# Altium schematic sheet PAGE3 - HUB, USB, ETH.SchDoc
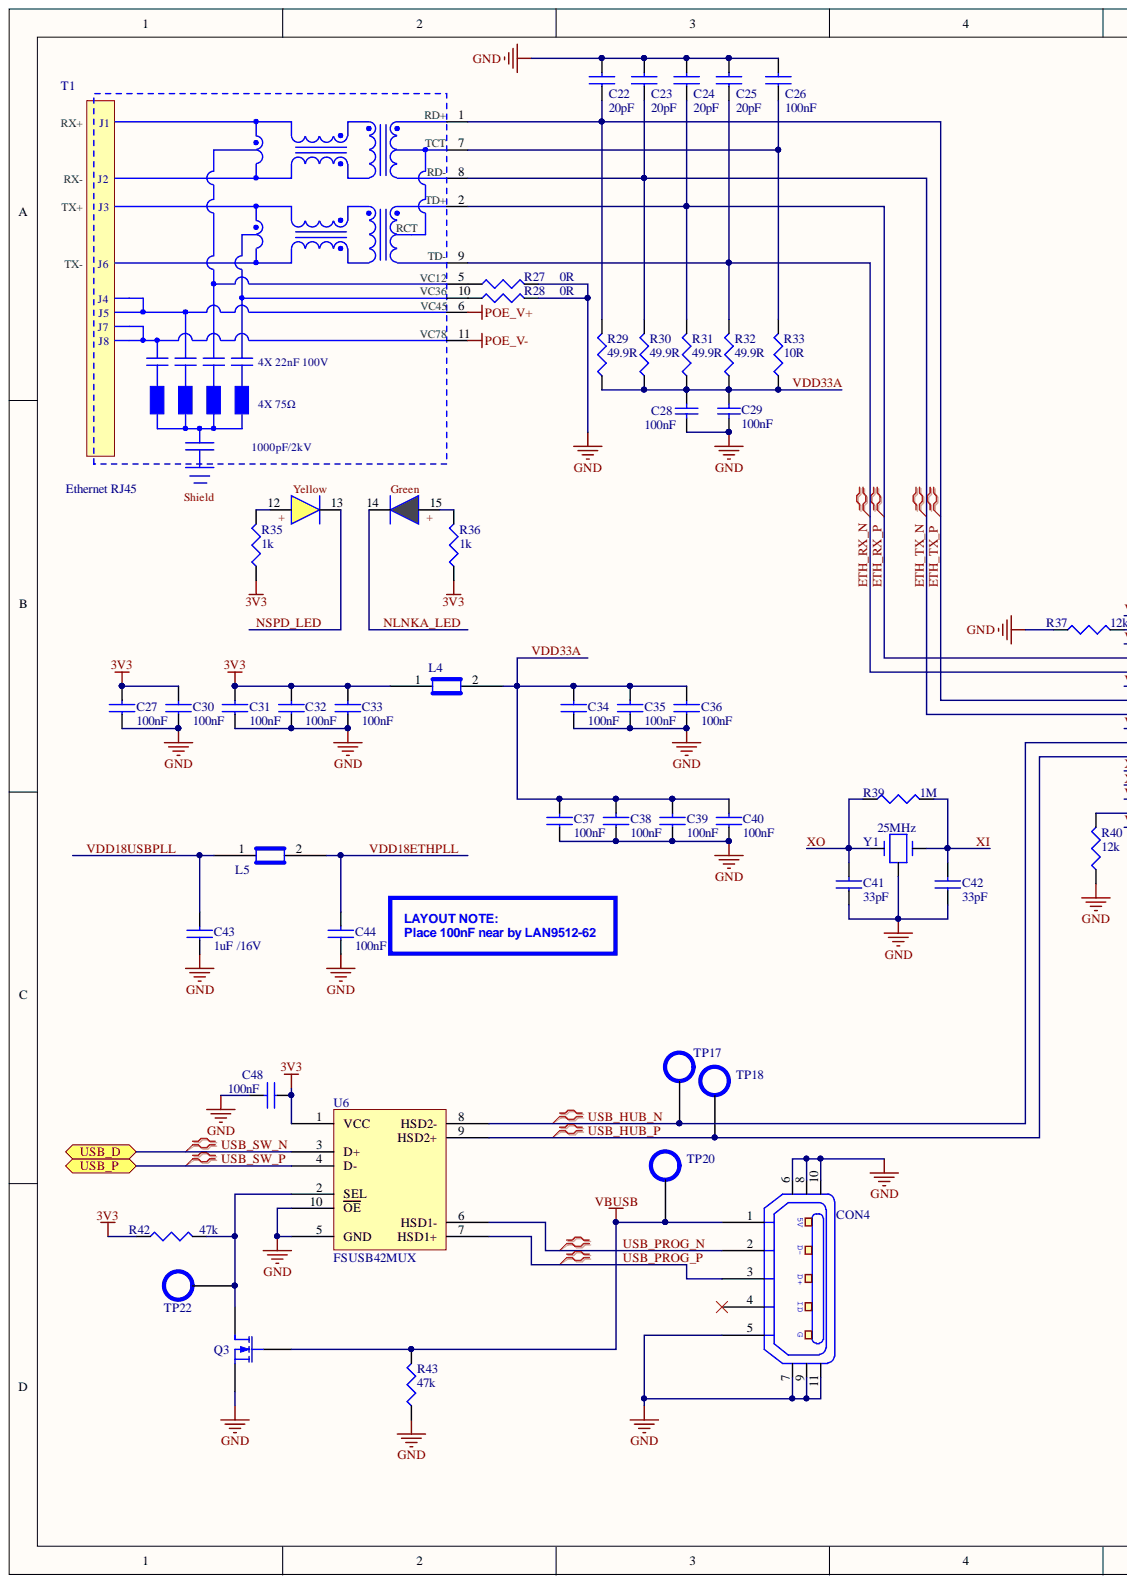
[diagram p0: PAGE3 - HUB, USB, ETH.SchDoc, left]
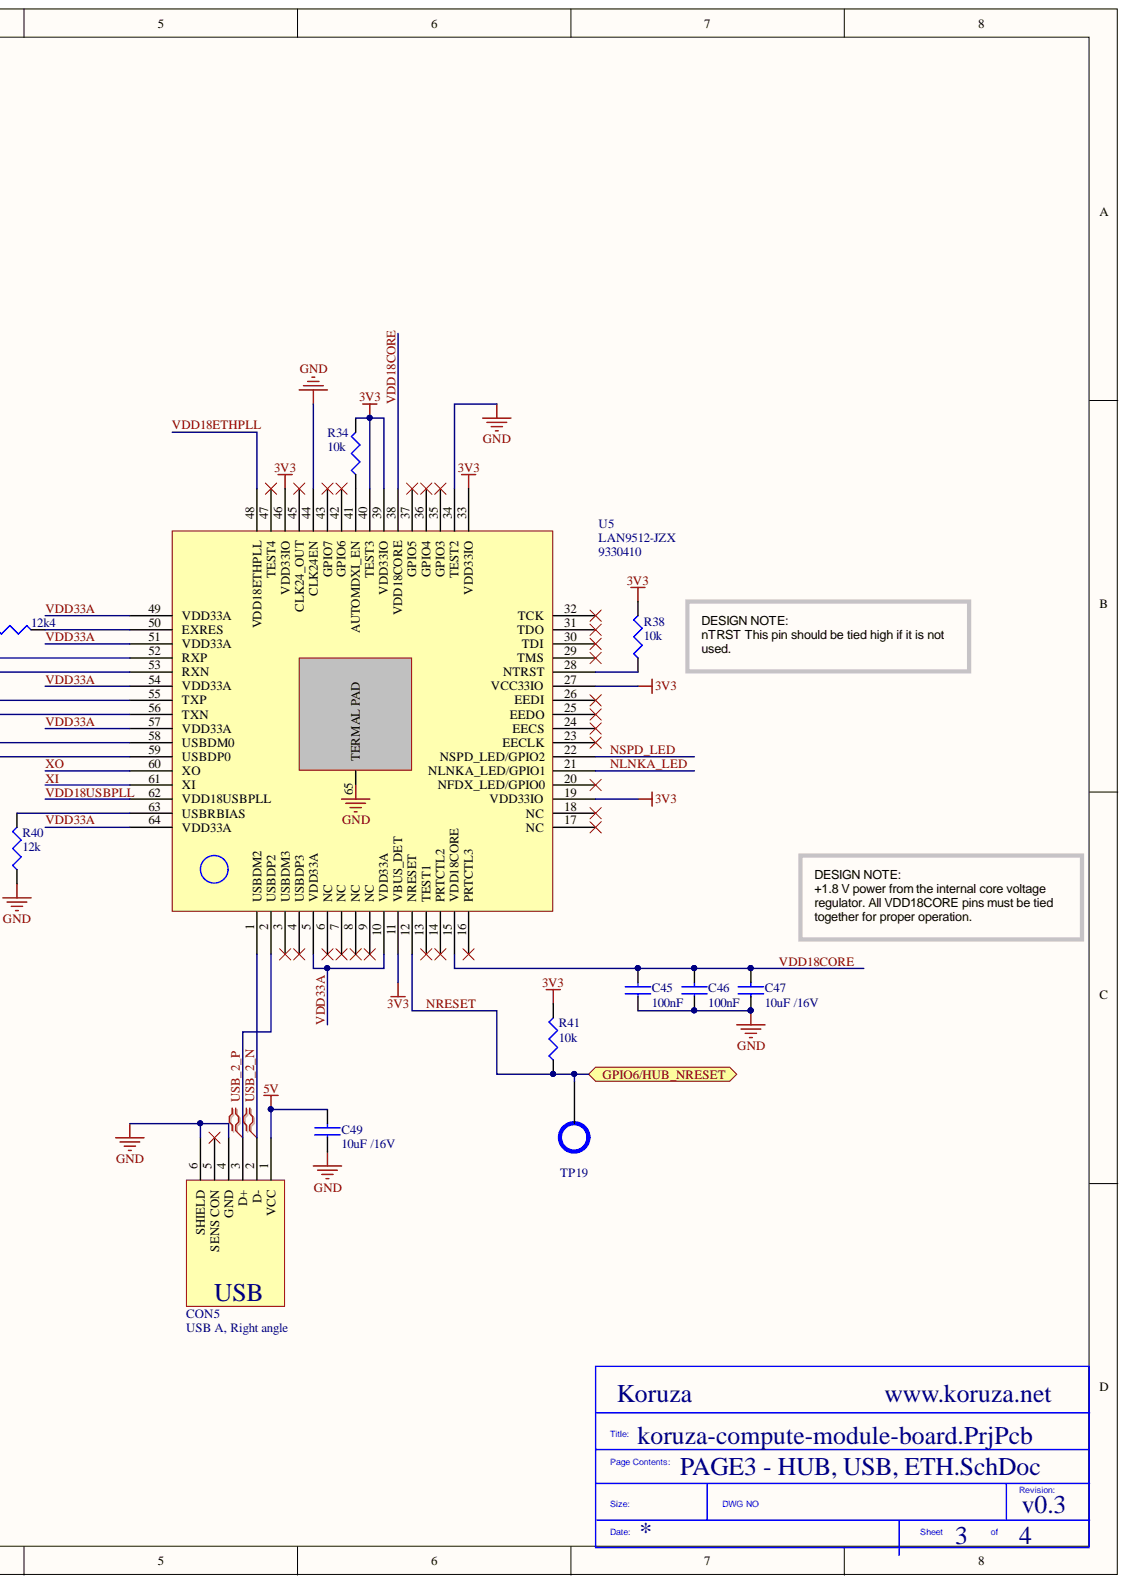
[diagram p1: PAGE3 - HUB, USB, ETH.SchDoc, right]

## Parts
C22  20pF  [CAP0603]
C23  20pF  [CAP0603]
C24  20pF  [CAP0603]
C25  20pF  [CAP0603]
C26  100nF  [CAP0603]
C27  100nF  [CAP0603]
C28  100nF  [CAP0603]
C29  100nF  [CAP0603]
C30  100nF  [CAP0603]
C31  100nF  [CAP0603]
C32  100nF  [CAP0603]
C33  100nF  [CAP0603]
C34  100nF  [CAP0603]
C35  100nF  [CAP0603]
C36  100nF  [CAP0603]
C37  100nF  [CAP0603]
C38  100nF  [CAP0603]
C39  100nF  [CAP0603]
C40  100nF  [CAP0603]
C41  33pF  [CAP0603]
C42  33pF  [CAP0603]
C43  1uF /16V  [CAP0603]
C44  100nF  [CAP0603]
C45  100nF  [CAP0603]
C46  100nF  [CAP0603]
C47  10uF /16V  [CAP0603]
C48  100nF  [CAP0603]
C49  10uF /16V  [CAP0603]
CON4  10104110-0001LF  [10118193]  (value not drawn)
CON5  USB A, Right angle  [USB A, TH, Right Angle]
L4  BLM18EG221SN1D  [CAP0603]  (value not drawn)
L5  BLM18EG221SN1D  [CAP0603]  (value not drawn)
Q3  DMG1012T-7  [DMG1012T-7]  (value not drawn)
R27  0R  [RES0603]
R28  0R  [RES0603]
R29  49.9R  [RES0603]
R30  49.9R  [RES0603]
R31  49.9R  [RES0603]
R32  49.9R  [RES0603]
R33  10R  [RES0603]
R34  10k  [RES0603]
R35  1k  [RES0603]
R36  1k  [RES0603]
R37  12k4  [RES0603]
R38  10k  [RES0603]
R39  1M  [RES0603]
R40  12k  [RES0603]
R41  10k  [RES0603]
R42  47k  [RES0603]
R43  47k  [RES0603]
T1  Ethernet RJ45  USOC Codes: RJ45  [0826-1L1T-57-F]
TP17  TEST_POINT  [TEST_POINT]  (value not drawn)  (no BOM)
TP18  TEST_POINT  [TEST_POINT]  (value not drawn)  (no BOM)
TP19  TEST_POINT  [TEST_POINT]  (value not drawn)  (no BOM)
TP20  TEST_POINT  [TEST_POINT]  (value not drawn)  (no BOM)
TP22  TEST_POINT  [TEST_POINT]  (value not drawn)  (no BOM)
U5  LAN9512-JZX  Supplier Part Number 2: 9330410  [QFN64]
U6  FSUSB42MUX  [MO-187]
Y1  25MHz  [XTAL_small]

## Ports
GPIO6/HUB_NRESET, USB_D, USB_P

## Nets
3V3: C27.2 C30.2 C31.2 C32.2 C33.2 C48.1 L4.1 R34.2 R35.1 R36.1 R38.2 R41.2 R42.1 U5.11(VBUS_DET) U5.19(VDD33IO) U5.27(VCC33IO) U5.33(VDD33IO) U5.39(VDD33IO) U5.40(TEST3) U5.46(VDD33IO) U6.1(VCC)
5V: C49.2 CON5.1(VCC)
GND: C22.2 C23.2 C24.2 C25.2 C26.2 C27.1 C28.1 C29.1 C30.1 C31.1 C32.1 C33.1 C34.1 C35.1 C36.1 C37.1 C38.1 C39.1 C40.1 C41.1 C42.1 C43.1 C44.1 C45.1 C46.1 C47.1 C48.2 C49.1 CON4.5 CON4.6 CON4.7 CON4.8 CON4.9 CON4.10 CON4.11 CON5.4(GND) CON5.6(SHIELD) Q3.3 R27.2 R28.2 R37.1 R40.1 R43.1 U5.34(TEST2) U5.44(CLK24EN) U5.65 U6.5(GND) U6.10(OE) Y1.3
POE_V+: T1.6
POE_V-: T1.11
VBUSB: CON4.1 Q3.2 R43.2 TP20.1
ETH_RX_N: C25.1 R32.2 T1.9 U5.53(RXN)
ETH_RX_P: C24.1 R31.2 T1.2(TD+) U5.52(RXP)
ETH_TX_N: C23.1 R30.2 T1.8(RD-) U5.56(TXN)
ETH_TX_P: C22.1 R29.2 T1.1(RD+) U5.55(TXP)
NLNKA_LED: T1.14 U5.21(NLNKA_LED/GPIO1)
NRESET: R41.1 TP19.1 U5.12(NRESET) ; port GPIO6/HUB_NRESET
NSPD_LED: T1.13 U5.22(NSPD_LED/GPIO2)
USB_2_N: CON5.2(D-) U5.1(USBDM2)
USB_2_P: CON5.3(D+) U5.2(USBDP2)
USB_HUB_N: TP17.1 U5.58(USBDM0) U6.8(HSD2-)
USB_HUB_P: TP18.1 U5.59(USBDP0) U6.9(HSD2+)
USB_PROG_N: CON4.2 U6.6(HSD1-)
USB_PROG_P: CON4.3 U6.7(HSD1+)
USB_SW_N: U6.3(D+) ; port USB_D
USB_SW_P: U6.4(D-) ; port USB_P
VDD18CORE: C45.2 C46.2 C47.2 U5.15(VDD18CORE) U5.38(VDD18CORE)
VDD18ETHPLL: C44.2 L5.2 U5.48(VDD18ETHPLL)
VDD18USBPLL: C43.2 L5.1 U5.62(VDD18USBPLL)
VDD33A: C28.2 C29.2 C34.2 C35.2 C36.2 C37.2 C38.2 C39.2 C40.2 L4.2 R29.1 R30.1 R31.1 R32.1 R33.1 U5.5(VDD33A) U5.10(VDD33A) U5.49(VDD33A) U5.51(VDD33A) U5.54(VDD33A) U5.57(VDD33A) U5.64(VDD33A)
XI: C42.2 R39.2 U5.61(XI) Y1.2
XO: C41.2 R39.1 U5.60(XO) Y1.1
NetC26_1: C26.1 R33.2 T1.7(TCT)
NetQ3_1: Q3.1 R42.2 TP22.1 U6.2(SEL)
NetR27_1: R27.1 T1.5(VC12)
NetR28_1: R28.1 T1.10
NetR34_1: R34.1 U5.41(AUTOMDXI_EN)
NetR35_2: R35.2 T1.12
NetR36_2: R36.2 T1.15
NetR37_2: R37.2 U5.50(EXRES)
NetR38_1: R38.1 U5.28(NTRST)
NetR40_2: R40.2 U5.63(USBRBIAS)

Not connected: CON4 4; CON5 5(SENS_CON); U5 3(USBDM3) 4(USBDP3) 6(NC) 7(NC) 8(NC) 9(NC) 13(TEST1) 14(PRTCTL2) 16(PRTCTL3) 17(NC) 18(NC) 20(NFDX_LED/GPIO0) 23(EECLK) 24(EECS) 25(EEDO) 26(EEDI) 29(TMS) 30(TDI) 31(TDO) 32(TCK) 35(GPIO3) 36(GPIO4) 37(GPIO5) 42(GPIO6) 43(GPIO7) 45(CLK24_OUT) 47(TEST4)

## Library data of the parts
C22-C25 = GRM1885C1H200JA01D: MURATA - GRM1885C1H200JA01D - CAPACITOR, MLCC, C0G, 20PF, 50V, 0603; Capacitance: 20; Capacitance Tolerance: ± 5%; Ceramic Capacitor Case: 0603 [1608 Metric]; Dielectric Characteristic: C0G / NP0; Product Range: GRM Series; SVHC: No SVHC (17-Dec-2015; Voltage Rating: 50; Automotive Qualification Standard: -; Component Kind: Standard; ComponentLink1Description: http://www.farnell.com/datasheets/2048077.pdf; ComponentLink1URL: http://www.farnell.com/datasheets/2048077.pdf; Library Name: SCHLib.SchLib; Manufacturer: MURATA; Manufacturer Part Number: GRM1885C1H200JA01D; MSL: MSL 1 - Unlimited; Operating Temperature Max: 125; Operating Temperature Min: -55; Packaging: Cut Tape; Pricing 1: 10=0.0245, 100=0.0159, 500=0.0123, 1000=0.0095, 2000=0.0093, 4000=0.0073, 20000=0.0062, 40000=0.0057 (GBP); RoHS: YES; Stock 1: 5812; Supplier 1: Farnell; Supplier Part Number 1: 2456108
C26 C27 C30-C40 C44-C46 C48 = 100nF: MURATA - GRM188R71C104KA01J - CAP, MLCC, X7R, 0.1UF, 16V, 0603; Capacitance: 0.1; Capacitance Tolerance: ± 10%; Ceramic Capacitor Case: 0603 [1608 Metric]; Dielectric Characteristic: X7; Product Range: GRM Series; SVHC: No SVHC (20-Jun-2016; Voltage Rating: 16; Automotive Qualification Standard: -; Component Kind: Standard; ComponentLink1Description: http://www.farnell.com/datasheets/2189124.pdf; ComponentLink1URL: http://www.farnell.com/datasheets/2189124.pdf; Library Name: SCHLib.SchLib; Manufacturer: MURATA; Manufacturer Part Number: GRM188R71C104KA01J; MSL: MSL 1 - Unlimited; Operating Temperature Max: 125; Operating Temperature Min: -55; Packaging: Cut Tape; Pricing 1: 10=0.0145, 100=0.0126, 500=0.0081, 2500=0.0059, 5000=0.0052, 10000=0.0046, 50000=0.0036, 100000=0.0035 (GBP); RoHS: YES; Stock 1: 18297; Supplier 1: Farnell; Supplier Part Number 1: 2688519
C28 C29 = Cap: Capacitor; Component Kind: Standard; LatestRevisionDate: 17-Jul-2002; LatestRevisionNote: Re-released for DXP Platform.; Library Name: Miscellaneous Devices.IntLib; PackageDescription: Radial Cap, Thru-Hole; 2 Leads; 0.3 in Pin Spacing; PackageReference: RAD-0.3; Published: 8-Jun-2000; Signal Integrity: Cap; Simulation: CAP; Value: 100nF; Publisher: Altium Limited
C41 C42 = GRM1885C1H330JA01D: MURATA - GRM1885C1H330JA01D - CAP, MLCC, C0G/NP0, 33PF, 50V, 0603; Capacitance: 33; Capacitance Tolerance: ± 5%; Capacitor Terminals: SMD<!--FANDB--><div class="features-and-benefits-container"><div class="features-and-benefits-description"><span class="features-benefits-value">The Murata GRM series capacitors are Multilayer Ceramic Chip Capacitors (MLCC) used for general purpose applications. These MLCC capacitors are classified into temperature compensating and high dielectric constant types depending on the change in electrostatic capacitance due to change in temperature. The temperature compensating capacitors feature a small rate of change in electrostatic capacitance as the temperature changes and are used for applications such as filters and high frequency circuit matching. The high dielectric constant capacitors use materials with a high dielectric constant and feature a large electrostatic capacitance. Typical applications are power supply decoupling and smoothing circuits.</span></div><div class="features-and-benefits-list"><ul class="features-and-benefits-list-list"><li class="features-and-benefits-list-item">Large capacity and small size in a multilayer structure</li><li class="features-and-benefits-list-item">Capacitance value ranging from 0.1pF to 220?F</li><li class="features-and-benefits-list-item">Tin plated external electrodes, excellent solderability</li><li class="features-and-benefits-list-item">Provides good high frequency characteristics</li><li class="features-and-benefits-list-item">Excellent pulse response</li><li class="features-and-benefits-list-item">High reliability</li><li class="features-and-benefits-list-item">Capacitor size varies from 0.4×0.2mm to 5.7×5.0mm</li><li class="features-and-benefits-list-item">Rated voltage of 2.5VDC to 3.15; Ceramic Capacitor Case: 0603 [1608 Metric]; Product Range: GRM Series; SVHC: No SVHC (17-Dec-2015); Voltage Rating: 50; Automotive Qualification Standard: -; Capacitance Tolerance ±: ± 5%; Component Kind: Standard; ComponentLink1Description: http://www.farnell.com/datasheets/2048656.pdf; ComponentLink1URL: http://www.farnell.com/datasheets/2048656.pdf; Dielectric Characteristic: C0G / NP0; Library Name: SCHLib.SchLib; Manufacturer: MURATA; Manufacturer Part Number: GRM1885C1H330JA01D; MSL: -; Operating Temperature Max: 125; Operating Temperature Min: -55; Packaging: Cut Tape; Pricing 1: 10=0.0153, 100=0.0104, 500=0.0085, 1000=0.0069, 2000=0.0063 (GBP); RoHS: YES; Stock 1: 11752; Supplier 1: Farnell; Supplier Part Number 1: 1828910
C43 = GRM188R61C105KA12D: MURATA - GRM188R61C105KA12D - CAP, MLCC, X5R, 1UF, 16V, 0603; Capacitance: 1; Capacitance Tolerance: ± 10%; Capacitance Tolerance ±: ± 10%<!--FANDB--><div class="features-and-benefits-container"><div class="features-and-benefits-description"><span class="features-benefits-value">The Murata GRM series capacitors are Multilayer Ceramic Chip Capacitors (MLCC) used for general purpose applications. These MLCC capacitors are classified into temperature compensating and high dielectric constant types depending on the change in electrostatic capacitance due to change in temperature. The temperature compensating capacitors feature a small rate of change in electrostatic capacitance as the temperature changes and are used for applications such as filters and high frequency circuit matching. The high dielectric constant capacitors use materials with a high dielectric constant and feature a large electrostatic capacitance. Typical applications are power supply decoupling and smoothing circuits.</span></div><div class="features-and-benefits-list"><ul class="features-and-benefits-list-list"><li class="features-and-benefits-list-item">Large capacity and small size in a multilayer structure</li><li class="features-and-benefits-list-item">Capacitance value ranging from 0.1pF to 220?F</li><li class="features-and-benefits-list-item">Tin plated external electrodes, excellent solderability</li><li class="features-and-benefits-list-item">Provides good high frequency characteristics</li><li class="features-and-benefits-list-item">Excellent pulse response</li><li class="features-and-benefits-list-item">High reliability</li><li class="features-and-benefits-list-item">Capacitor size varies from 0.4×0.2mm to 5.7×5.0mm</li><li class="features-and-benefits-list-item">Rated voltage of 2.5VDC to 3.15; Ceramic Capacitor Case: 0603 [1608 Metric]; Dielectric Characteristic: X5; Product Range: GRM Series; SVHC: No SVHC (17-Dec-2015); Voltage Rating: 16; Automotive Qualification Standard: -; Component Kind: Standard; ComponentLink1Description: http://www.farnell.com/datasheets/2047881.pdf; ComponentLink1URL: http://www.farnell.com/datasheets/2047881.pdf; ComponentLink2Description: http://www.farnell.com/datasheets/2006461.pdf; ComponentLink2URL: http://www.farnell.com/datasheets/2006461.pdf; Library Name: SCHLib.SchLib; Manufacturer: MURATA; Manufacturer Part Number: GRM188R61C105KA12D; MSL: MSL 1 - Unlimited; Operating Temperature Max: 85; Operating Temperature Min: -55; Packaging: Cut Tape; Pricing 1: 10=0.0194, 100=0.013, 500=0.0101, 1000=0.0078, 2000=0.0074 (GBP); RoHS: YES; Stock 1: 27380; Supplier 1: Farnell; Supplier Part Number 1: 2362099RL
C47 C49 = GRM188R61C106MA73D: MURATA - GRM188R61C106MA73D - CAP, MLCC, X5R, 10UF, 16V, 0603; Capacitance: 10; Capacitance Tolerance: ± 20%; Ceramic Capacitor Case: 0603 [1608 Metric]; Dielectric Characteristic: X5; Product Range: GRM Series; SVHC: No SVHC (17-Dec-2015)<!--FANDB--><div class="features-and-benefits-container"><div class="features-and-benefits-description"><span class="features-benefits-value">The Murata GRM series capacitors are Multilayer Ceramic Chip Capacitors (MLCC) used for general purpose applications. These MLCC capacitors are classified into temperature compensating and high dielectric constant types depending on the change in electrostatic capacitance due to change in temperature. The temperature compensating capacitors feature a small rate of change in electrostatic capacitance as the temperature changes and are used for applications such as filters and high frequency circuit matching. The high dielectric constant capacitors use materials with a high dielectric constant and feature a large electrostatic capacitance. Typical applications are power supply decoupling and smoothing circuits.</span></div><div class="features-and-benefits-list"><ul class="features-and-benefits-list-list"><li class="features-and-benefits-list-item">Large capacity and small size in a multilayer structure</li><li class="features-and-benefits-list-item">Capacitance value ranging from 0.1pF to 220?F</li><li class="features-and-benefits-list-item">Tin plated external electrodes, excellent solderability</li><li class="features-and-benefits-list-item">Provides good high frequency characteristics</li><li class="features-and-benefits-list-item">Excellent pulse response</li><li class="features-and-benefits-list-item">High reliability</li><li class="features-and-benefits-list-item">Capacitor size varies from 0.4×0.2mm to 5.7×5.0mm</li><li class="features-and-benefits-list-item">Rated voltage of 2.5VDC to 3.15; Voltage Rating: 16; Automotive Qualification Standard: -; Component Kind: Standard; ComponentLink1Description: http://www.farnell.com/datasheets/2048226.pdf; ComponentLink1URL: http://www.farnell.com/datasheets/2048226.pdf; Library Name: SCHLib.SchLib; Manufacturer: MURATA; Manufacturer Part Number: GRM188R61C106MA73D; MSL: -; Operating Temperature Max: 85; Operating Temperature Min: -55; Packaging: Cut Tape; Pricing 1: 10=0.181, 100=0.103, 500=0.0863, 1000=0.0778, 2000=0.0677 (GBP); RoHS: YES; Stock 1: 21735; Supplier 1: Farnell; Supplier Part Number 1: 2426958
CON4 = 10104110-0001LF: AMPHENOL FCI - 10104110-0001LF - MICRO USB, 2.0 TYPE B, RECEPTACLE, SMT; Connector Mounting: Surface Mount; Connector Type: USB Micro B; Contact Termination: Surface Mount Right Angle; No. of Contacts: 5Contacts<!--FANDB--><div class="features-and-benefits-container"><div class="features-and-benefits-description"><span class="features-benefits-value">The 10104110-0001LF is a micro-USB type-B Receptacle Connector, comply with physical, electrical and environmental requirements of micro-USB standard (USB 2.0). Space receptacles and plugs are available in a wide variety of mounting and termination configurations, permitting their use in various applications. Compared with the standard mini-B connectors, the size is reduced by approximately 60% while still allowing a high-speed data transfer of 480; No. of Positions: 5; SVHC: No SVHC (15-Jun-2015); USB Connector Type: Micro USB Type B; USB Standard: USB 2.0; Component Kind: Standard; ComponentLink1Description: http://www.farnell.com/datasheets/1469072.pdf; ComponentLink1URL: http://www.farnell.com/datasheets/1469072.pdf; Contact Material: Copper Alloy; Contact Plating: Gold Plated Contacts; Contact Termination Type: Surface Mount; Gender: Receptacle; Library Name: SCHLib.SchLib; Manufacturer: AMPHENOL FCI; Manufacturer Part Number: 10104110-0001LF; Orientation: Right Angle; Pricing 1: 1=0.464, 10=0.451, 25=0.437, 50=0.424, 100=0.41, 250=0.291 (GBP); Product Range: -; RoHS: YES; Stock 1: 25585; Supplier 1: Farnell; Supplier Part Number 1: 2293753
CON5 = 73725-0110BLF: AMPHENOL FCI - 73725-0110BLF - USB, 2.0 TYPE A, RECEPTACLE, TH; Connector Mounting: Through Hole Mount; Connector Type: USB A; Contact Termination: Through Hole Right Angle; Contact Termination Type: Through Hole; No. of Contacts: 4Contacts<!--FANDB--><div class="features-and-benefits-container"><div class="features-and-benefits-description"><span class="features-benefits-value">The 73725-0110BLF is a right angle USB type-A Receptacle Connector with single stack, 30?inch GXT contact and glass fibre filled thermoplastic housing.</span></div><div class="features-and-benefits-list"><ul class="features-and-benefits-list-list"><li class="features-and-benefits-list-item">Through Hole Mounting</li><li class="features-and-benefits-list-item">Up Right Pin Orientation</li><li class="features-and-benefits-list-item">Pure Tin-plated Shell</li><li class="features-and-benefits-list-item">100; No. of Positions: 4; SVHC: No SVHC (15-Jun-2015); USB Connector Type: USB Type A; USB Standard: USB 2.0; Component Kind: Standard; ComponentLink1Description: http://www.farnell.com/cad/2043081.pdf; ComponentLink1URL: http://www.farnell.com/cad/2043081.pdf; ComponentLink2Description: http://www.farnell.com/datasheets/1918629.pdf; ComponentLink2URL: http://www.farnell.com/datasheets/1918629.pdf; Contact Material: Copper Alloy; Contact Plating: Gold Plated Contacts; Gender: Receptacle; Library Name: SCHLib.SchLib; Manufacturer: AMPHENOL FCI; Manufacturer Part Number: 73725-0110BLF; Orientation: Right Angle; Pricing 1: 1=0.476, 10=0.433, 100=0.39, 500=0.353, 1000=0.316, 2500=0.31, 9000=0.303 (GBP); Product Range: -; RoHS: YES; Stock 1: 6842; Supplier 1: Farnell; Supplier Part Number 1: 2112380
L4 L5 = BLM18EG221SN1D: MURATA - BLM18EG221SN1D - FERRITE BEAD, 0.05OHM, 2A, 0603; DC Current Rating: 2; DC Resistance Max: 0.05; Ferrite Case Style: 0603 [1608 Metric]; Ferrite Mounting: SMD; Impedance @ 100MHz: 220; Impedance Tolerance: ± 25%; Product Range: BLM18; Resistance: 0.05ohm<!--FANDB--><div class="features-and-benefits-container"><div class="features-and-benefits-description"><span class="features-benefits-value">The Murata BLM18EG series are EMIFIL chip ferrite beads for suppressing high frequency noise in GHz band general signal line applications. These chip ferrite beads are designed for reducing the possibility of resonance to provide undistorted signal waveform. EMIFIL chip ferrite beads do not require connection to ground so they are effectively used in circuits without stable ground lines. The nickel barrier structure of the external electrodes provides excellent solder heat resistance. BLM18EG series has modified internal electrode structure that minimizes stray capacitance and increases the effective frequency range.</span></div><div class="features-and-benefits-list"><ul class="features-and-benefits-list-list"><li class="features-and-benefits-list-item">EIA 0603 standard chip size</li><li class="features-and-benefits-list-item">Miniaturized ferrite beads</li><li class="features-and-benefits-list-item">Effective at low impedance line</li><li class="features-and-benefits-list-item">Available in selective impedance range values at 100MHz</li><li class="features-and-benefits-list-item">Low DC resistance</li><li class="features-and-benefits-list-item">Large rated current</li><li class="features-and-benefits-list-item">Excellent direct current characteristics</li><li class="features-and-benefits-list-item">Suitable for small and low profile equipments</li><li class="features-and-benefits-list-item">Operating temperature range -55°C to 125; SVHC: No SVHC (17-Dec-2015); Automotive Qualification Standard: -; Component Kind: Standard; ComponentLink1Description: http://www.farnell.com/datasheets/5169.pdf; ComponentLink1URL: http://www.farnell.com/datasheets/5169.pdf; Core Material: Ferrite; Library Name: SCHLib.SchLib; Manufacturer: MURATA; Manufacturer Part Number: BLM18EG221SN1D; Operating Temperature Max: +125; Operating Temperature Min: -55; Packaging: Cut Tape; Pricing 1: 10=0.0777, 100=0.064, 500=0.0516, 1000=0.038, 2000=0.033, 4000=0.03, 20000=0.0295, 40000=0.0262 (GBP); RoHS: YES; Stock 1: 36495; Supplier 1: Farnell; Supplier Part Number 1: 1515716
Q3 = DMG1012T-7: MOSFET MOSFET N-CHANNEL SOT-523; Brand: Diodes Incorporated; Channel Mode: Enhancement; Configuration: 1 N-Channel; Fall Time: 12.3 ns; Id - Continuous Drain Current: 630 mA; Number of Channels: 1 Channel; Pd - Power Dissipation: 280 mW; Qg - Gate Charge: 736.6 pC; Rds On - Drain-Source Resistance: 300 mOhms; Rise Time: 7.4 ns; Typical Turn-Off Delay Time: 26.7 ns; Typical Turn-On Delay Time: 5.1 ns; Vds - Drain-Source Breakdown Voltage: 20 V; Vgs - Gate-Source Voltage: +/- 6 V; Vgs th - Gate-Source Threshold Voltage: 500 mV; Category: MOSFET; Component Kind: Standard; ComponentLink1Description: http://www.mouser.com/ds/2/115/ds31783-44973.pdf; ComponentLink1URL: http://www.mouser.com/ds/2/115/ds31783-44973.pdf; Library Name: SCHLib.SchLib; Manufacturer: Diodes Incorporated; Manufacturer Part Number: DMG1012T-7; Maximum Operating Temperature: + 150 C; Minimum Operating Temperature: - 55 C; Minimum Order Quantity: 1; Mounting Style: SMD/SMT; Package/Case: SOT-523-3; Packaging: Reel; Pricing 1: 1=0.273, 10=0.187, 100=0.077, 1000=0.053, 3000=0.041, 9000=0.035, 24000=0.033, 45000=0.031, 99000=0.027 (EUR); Product: MOSFET Small Signal; Reeling: True; RoHS: RoHS Compliant; RoHS - Mouser: Y; Series: DMG1012; Standard Pack Qty: 3000; Stock 1: 1195; Supplier 1: Mouser; Supplier Part Number 1: 621-DMG1012T-7; Technology: Si; Transistor Polarity: N-Channel; Transistor Type: 1 N-Channel
R27 R28 = CR0603-J/-000ELF: BOURNS - CR0603-J/-000ELF - RES, THICK FILM, 0R, 5%, 0.1W, 0603; Power Rating: 100; Product Range: CR Series; Resistance: 0; Resistor Case Style: 0603 [1608 Metric]; Resistor Mounting: Surface Mount Device<!--FANDB--><div class="features-and-benefits-container"><div class="features-and-benefits-description"><span class="features-benefits-value">The Bourns CR series are standard thick film chip resistors. These resistors provide flexibility of performance, space saving and low cost. The Resistance range includes E96 and E24 decade values from 1ohm to 10Mohm.</span></div><div class="features-and-benefits-list"><ul class="features-and-benefits-list-list"><li class="features-and-benefits-list-item">0201, 0402, 0603, 0805, 1206, 1210, 2010, 2512 standard case sizes available</li><li class="features-and-benefits-list-item">±1% and ±5% tolerance</li><li class="features-and-benefits-list-item">±100ppm/°C, ±200ppm/°C and ±250ppm/°C TCR values available</li><li class="features-and-benefits-list-item">Power rating selectable for 50mW, 63mW, 0.1W, 0.125W, 0.25W, 0.5W and 1W based on ordering</li><li class="features-and-benefits-list-item">Jumpers are available for all size</li><li class="features-and-benefits-list-item">3 layer termination process with nickel barrier prevents leaching & provides excellent solderability</li><li class="features-and-benefits-list-item">Suitable for all type of soldering processes</li><li class="features-and-benefits-list-item">Tight dimensional tolerances</li><li class="features-and-benefits-list-item">Maximum working voltage depends on case size</li><li class="features-and-benefits-list-item">Operating temperature of -55°C to +125°C(CR0201) and -55°C to +155; SVHC: No SVHC (17-Dec-2015); Voltage Rating: 50; Automotive Qualification Standard: -; Component Kind: Standard; ComponentLink1Description: http://www.bourns.com/engineering/CHPREZTR/cr0603.stp; ComponentLink1URL: http://www.bourns.com/engineering/CHPREZTR/cr0603.stp; ComponentLink2Description: http://www.farnell.com/datasheets/2178762.pdf; ComponentLink2URL: http://www.farnell.com/datasheets/2178762.pdf; Library Name: SCHLib.SchLib; Manufacturer: BOURNS; Manufacturer Part Number: CR0603-J/-000ELF; MSL: MSL 1 - Unlimited; Packaging: Cut Tape; Pricing 1: 10=0.0146, 250=0.0097, 1000=0.0073, 5000=0.0049, 12500=0.0042 (GBP); Resistance Tolerance: ± 5%; Resistor Element Material: Thick Film; RoHS: YES; Stock 1: 58200; Supplier 1: Farnell; Supplier Part Number 1: 2008343; Temperature Coefficient: -
R29-R32 = CRCW060349R9FKEA: VISHAY - CRCW060349R9FKEA - RES, THICK FILM, 49R9, 1%, 0.1W, 0603; Automotive Qualification Standard: AEC-Q200; Power Rating: 100; Product Range: CRCW Series; Resistance: 49.9; Resistor Case Style: 0603 [1608 Metric]; Resistor Mounting: Surface Mount Device<!--FANDB--><div class="features-and-benefits-container"><div class="features-and-benefits-description"><span class="features-benefits-value">The Vishay D/CRCW e3 series are standard thick film chip resistors. The resistance range includes E96 and E24 decade values from 1ohm to 10Mohm. CRCW e3 series resistors have a pure tin solder contacts on the Ni barrier layer that provides compatibility with reflow soldering process.</span></div><div class="features-and-benefits-list"><ul class="features-and-benefits-list-list"><li class="features-and-benefits-list-item">Automotive grade AEC-Q200 qualified</li><li class="features-and-benefits-list-item">EIA 0402, 0603, 0805, 1206, 1210, 1218, 2010, 2512 standard case sizes available</li><li class="features-and-benefits-list-item">Stability &Delta; Temperature Coefficient: ± 100; Voltage Rating: 75; Component Kind: Standard; ComponentLink1Description: http://www.farnell.com/datasheets/2111203.pdf; ComponentLink1URL: http://www.farnell.com/datasheets/2111203.pdf; ComponentLink2Description: http://www.farnell.com/cad/1552291.zip; ComponentLink2URL: http://www.farnell.com/cad/1552291.zip; Library Name: SCHLib.SchLib; Manufacturer: VISHAY; Manufacturer Part Number: CRCW060349R9FKEA; MSL: MSL 1 - Unlimited; Packaging: Cut Tape; Pricing 1: 10=0.0158, 100=0.0094, 500=0.0071, 1000=0.0052, 2500=0.0047 (GBP); Resistance Tolerance: ± 1%; Resistor Element Material: Thick Film; RoHS: Y-EX; Stock 1: 45411; Supplier 1: Farnell; Supplier Part Number 1: 1469817
R33 = RCA060310R0FKEA: VISHAY - RCA060310R0FKEA - RES, AUTO, THICK FILM, 10R, 1%, 0603; Automotive Qualification Standard: AEC-Q200; Power Rating: 100; Product Range: RCA Series; Resistance: 10; Resistor Case Style: 0603 [1608 Metric]; SVHC: No SVHC (17-Dec-2015)<!--FANDB--><div class="features-and-benefits-container"><div class="features-and-benefits-description"><span class="features-benefits-value">The RCA series from Vishay are automotive grade, sulphur resistant thick film rectangular chip resistors. The resistance range includes E24 and E96 decade values from 1ohm to 10Mohm. They provide superior resistance against H2S atmosphere. These resistors have metal glaze on high quality ceramic.</span></div><div class="features-and-benefits-list"><ul class="features-and-benefits-list-list"><li class="features-and-benefits-list-item">Automotive grade AEC-Q200 qualified (rev C)</li><li class="features-and-benefits-list-item">EIA 0402, 0603, 0805, 1206, 1210, 1218, 2010 and 2512 case sizes available</li><li class="features-and-benefits-list-item">Available in ±0.5%, ±1% and ±5% tolerances</li><li class="features-and-benefits-list-item">Jumpers are also available</li><li class="features-and-benefits-list-item">±50ppm/K, ±100ppm/K and ±200ppm/K TCR values</li><li class="features-and-benefits-list-item">Power rating of 0.063W, 0.10W, 0.125W, 0.25W, 0.5W, 0.75W and 1W at 70?C</li><li class="features-and-benefits-list-item">Available in 50V, 75V, 150V, 200V, 400V and 500V limiting voltage options</li><li class="features-and-benefits-list-item">Category temperature range from -55?C to 155?C</li><li class="features-and-benefits-list-item">Stability ?R/R = 1 % for 1000h at 70; Temperature Coefficient: ± 100; Voltage Rating: 75; Component Kind: Standard; ComponentLink1Description: http://www.farnell.com/datasheets/2111201.pdf; ComponentLink1URL: http://www.farnell.com/datasheets/2111201.pdf; Library Name: SCHLib.SchLib; Manufacturer: VISHAY; Manufacturer Part Number: RCA060310R0FKEA; Packaging: Cut Tape; Pricing 1: 10=0.0803, 100=0.0386, 500=0.0235, 2500=0.015, 5000=0.0135, 10000=0.0108, 50000=0.0068, 100000=0.0067 (GBP); Resistance Tolerance: ± 1%; Resistor Element Material: Thick Film; RoHS: YES; Stock 1: 9559; Supplier 1: Farnell; Supplier Part Number 1: 2616585
R34 R38 R41 = MCMR06X103 JTL: MULTICOMP - MCMR06X103 JTL - RES, CERAMIC, 10K, 5%, 0.1W, 0603; Automotive Qualification Standard: -<!--FANDB--><div class="features-and-benefits-container"><div class="features-and-benefits-description"><span class="features-benefits-value">The Multicomp MCMR series resistors are automotive grade, anti sulphured thick film chip resistor. The resistance range includes E24 and E96 decade values from 1ohm to 10Mohm. These resistors are constructed on top of a high grade ceramic body.</span></div><div class="features-and-benefits-list"><ul class="features-and-benefits-list-list"><li class="features-and-benefits-list-item">EIA 0402, 0603, 0805, 1206 chip sizes available</li><li class="features-and-benefits-list-item">High reliability and stability</li><li class="features-and-benefits-list-item">Automotive grade AEC-Q200 compliant</li><li class="features-and-benefits-list-item">Complaint with (IEC 60068-1) 55/155/56 climatic category</li><li class="features-and-benefits-list-item">Maximum operation voltage of 50V, 75V, 150V and 200V</li><li class="features-and-benefits-list-item">Power rating of 62.5mW, 100mW, 125mW and 250mW</li><li class="features-and-benefits-list-item">Available in ±1% and ±5% tolerance</li><li class="features-and-benefits-list-item">Temperature coefficient (TCR) of +200ppm/°C, +100ppm/°C and -200ppm/°C to +400ppm/°C</li><li class="features-and-benefits-list-item">Operating temperature range -55°C to 155; Power Rating: 100; Product Range: MCMR Series; Resistance: 10; Resistor Case Style: 0603 [1608 Metric]; Temperature Coefficient: ± 100; Voltage Rating: 75; Component Kind: Standard; ComponentLink1Description: http://www.farnell.com/datasheets/1716707.pdf; ComponentLink1URL: http://www.farnell.com/datasheets/1716707.pdf; Library Name: SCHLib.SchLib; Manufacturer: MULTICOMP; Manufacturer Part Number: MCMR06X103 JTL; Packaging: Cut Tape; Pricing 1: 10=0.0087, 100=0.0074, 500=0.0061, 1000=0.0048, 2500=0.0032, 5000=0.0024, 25000=0.0016, 50000=0.0013 (GBP); Resistance Tolerance: ± 5%; Resistor Element Material: Ceramic; RoHS: Y-EX; Stock 1: 19791; Supplier 1: Farnell; Supplier Part Number 1: 2073356
R35 R36 = MCMR06X102 JTL: MULTICOMP - MCMR06X102 JTL - RES, CERAMIC, 1K, 5%, 0.1W, 0603; Power Rating: 100; Product Range: MCMR Series; Resistance: 1; Resistor Case Style: 0603 [1608 Metric]; Resistor Mounting: Surface Mount Device<!--FANDB--><div class="features-and-benefits-container"><div class="features-and-benefits-description"><span class="features-benefits-value">The Multicomp MCMR series resistors are automotive grade, anti sulphured thick film chip resistor. The resistance range includes E24 and E96 decade values from 1ohm to 10Mohm. These resistors are constructed on top of a high grade ceramic body.</span></div><div class="features-and-benefits-list"><ul class="features-and-benefits-list-list"><li class="features-and-benefits-list-item">EIA 0402, 0603, 0805, 1206 chip sizes available</li><li class="features-and-benefits-list-item">High reliability and stability</li><li class="features-and-benefits-list-item">Automotive grade AEC-Q200 compliant</li><li class="features-and-benefits-list-item">Complaint with (IEC 60068-1) 55/155/56 climatic category</li><li class="features-and-benefits-list-item">Maximum operation voltage of 50V, 75V, 150V and 200V</li><li class="features-and-benefits-list-item">Power rating of 62.5mW, 100mW, 125mW and 250mW</li><li class="features-and-benefits-list-item">Available in ±1% and ±5% tolerance</li><li class="features-and-benefits-list-item">Temperature coefficient (TCR) of +200ppm/°C, +100ppm/°C and -200ppm/°C to +400ppm/°C</li><li class="features-and-benefits-list-item">Operating temperature range -55°C to 155; Temperature Coefficient: ± 100; Voltage Rating: 75; Automotive Qualification Standard: -; Component Kind: Standard; ComponentLink1Description: http://www.farnell.com/datasheets/1716707.pdf; ComponentLink1URL: http://www.farnell.com/datasheets/1716707.pdf; Library Name: SCHLib.SchLib; Manufacturer: MULTICOMP; Manufacturer Part Number: MCMR06X102 JTL; Packaging: Cut Tape; Pricing 1: 10=0.0089, 100=0.0076, 500=0.0063, 1000=0.0049, 2500=0.0033, 5000=0.0024, 25000=0.0016, 50000=0.0013 (GBP); Resistance Tolerance: ± 5%; Resistor Element Material: Ceramic; RoHS: Y-EX; Stock 1: 18133; Supplier 1: Farnell; Supplier Part Number 1: 2073354
R37 = MC0063W0603112K4: MULTICOMP - MC0063W0603112K4 - RES, THICK FILM, 12K4, 1%, 0.063W, 0603; External Depth: 0.45; External Length / Height: 1.6; External Width: 0.8; No. of Pins: 2; Power Rating: 63; Product Range: MC Series; Reel Quantity: 5000; Resistance: 12.4; Resistor Case Style: 0603 [1608 Metric]; Resistor Mounting: Surface Mount Device; SVHC: No SVHC (17-Dec-2015); Temperature Coeff. +: 100; Temperature Coefficient: ± 100; Voltage Rating: 50; Voltage Rating V DC: 50V<!--FANDB--><div class="features-and-benefits-container"><div class="features-and-benefits-description"><span class="features-benefits-value">The Multicomp MC series current sense resistors are thick film chip resistors. The resistance range includes E96 and E24 decade values from 1ohm to 10Mohm.</span></div><div class="features-and-benefits-list"><ul class="features-and-benefits-list-list"><li class="features-and-benefits-list-item">EIA 0402, 0603, 0805, 1206 chip sizes available</li><li class="features-and-benefits-list-item">Small size and low weight</li><li class="features-and-benefits-list-item">Suitable for both wave and reflow soldering</li><li class="features-and-benefits-list-item">Reduction of assembly costs</li><li class="features-and-benefits-list-item">Maximum working voltage of 50V(0402, 0603), 150V(0805), 200V(1206)</li><li class="features-and-benefits-list-item">Available in ±1%, ±2% and ±5% tolerance</li><li class="features-and-benefits-list-item">Power rating of 62.5mW, 100mW and 125mW</li><li class="features-and-benefits-list-item">±400ppm/°C(1ohm to 10ohm), ±200ppm/°C(11ohm to 100ohm), ±100; Automotive Qualification Standard: -; Component Kind: Standard; ComponentLink1Description: http://www.farnell.com/datasheets/1788326.pdf; ComponentLink1URL: http://www.farnell.com/datasheets/1788326.pdf; ComponentLink2Description: http://www.farnell.com/cad/1561155.zip; ComponentLink2URL: http://www.farnell.com/cad/1561155.zip; Library Name: SCHLib.SchLib; Manufacturer: MULTICOMP; Manufacturer Part Number: MC0063W0603112K4; Operating Temperature Max: 155; Operating Temperature Min: -55; Operating Temperature Range: -55°C to +155; Packaging: Cut Tape; Pricing 1: 10=0.0113, 1000=0.005, 1250=0.0046, 1750=0.0041, 2500=0.004 (GBP); Resistance Tolerance: ± 1%; Resistor Element Material: Thick Film; RoHS: Y-EX; Stock 1: 16712; Supplier 1: Farnell; Supplier Part Number 1: 1170897; Tape Width: 8; Temperature Coeff. -: 100; Tolerance +: 1%; Tolerance -: 1%
R39 = MC0063W060311M: MULTICOMP - MC0063W060311M - RES, THICK FILM, 1M, 1%, 0.063W, 0603; External Depth: 0.45; External Length / Height: 1.6; External Width: 0.8; No. of Pins: 2; Power Rating: 63; Product Range: MC Series; Reel Quantity: 5000; Resistance: 1; Resistor Case Style: 0603 [1608 Metric]; Resistor Mounting: Surface Mount Device; SVHC: No SVHC (17-Dec-2015); Temperature Coeff. +: 100; Temperature Coefficient: ± 100; Voltage Rating: 50; Voltage Rating V DC: 50V<!--FANDB--><div class="features-and-benefits-container"><div class="features-and-benefits-description"><span class="features-benefits-value">The Multicomp MC series current sense resistors are thick film chip resistors. The resistance range includes E96 and E24 decade values from 1ohm to 10Mohm.</span></div><div class="features-and-benefits-list"><ul class="features-and-benefits-list-list"><li class="features-and-benefits-list-item">EIA 0402, 0603, 0805, 1206 chip sizes available</li><li class="features-and-benefits-list-item">Small size and low weight</li><li class="features-and-benefits-list-item">Suitable for both wave and reflow soldering</li><li class="features-and-benefits-list-item">Reduction of assembly costs</li><li class="features-and-benefits-list-item">Maximum working voltage of 50V(0402, 0603), 150V(0805), 200V(1206)</li><li class="features-and-benefits-list-item">Available in ±1%, ±2% and ±5% tolerance</li><li class="features-and-benefits-list-item">Power rating of 62.5mW, 100mW and 125mW</li><li class="features-and-benefits-list-item">±400ppm/°C(1ohm to 10ohm), ±200ppm/°C(11ohm to 100ohm), ±100; Automotive Qualification Standard: -; Component Kind: Standard; ComponentLink1Description: http://www.farnell.com/datasheets/1788326.pdf; ComponentLink1URL: http://www.farnell.com/datasheets/1788326.pdf; ComponentLink2Description: http://www.farnell.com/cad/1558928.zip; ComponentLink2URL: http://www.farnell.com/cad/1558928.zip; Library Name: SCHLib.SchLib; Manufacturer: MULTICOMP; Manufacturer Part Number: MC0063W060311M; Operating Temperature Max: 155; Operating Temperature Min: -55; Operating Temperature Range: -55°C to +155; Packaging: Cut Tape; Pricing 1: 10=0.0011, 100=0.001, 500=0.0009, 1000=0.0008, 2500=0.0007 (GBP); Resistance Tolerance: ± 1%; Resistor Element Material: Thick Film; RoHS: Y-EX; Stock 1: 333415; Supplier 1: Farnell; Supplier Part Number 1: 9330410; Tape Width: 8; Temperature Coeff. -: 100; Tolerance +: 1%; Tolerance -: 1%
R40 = MC0063W0603112K: MULTICOMP - MC0063W0603112K - RES, THICK FILM, 12K, 1%, 0.063W, 0603; Power Rating: 63; Product Range: MC Series; Reel Quantity: 5000; Resistance: 12; Resistor Case Style: 0603 [1608 Metric]; Resistor Mounting: Surface Mount Device<!--FANDB--><div class="features-and-benefits-container"><div class="features-and-benefits-description"><span class="features-benefits-value">The Multicomp MC series current sense resistors are thick film chip resistors. The resistance range includes E96 and E24 decade values from 1ohm to 10Mohm.</span></div><div class="features-and-benefits-list"><ul class="features-and-benefits-list-list"><li class="features-and-benefits-list-item">EIA 0402, 0603, 0805, 1206 chip sizes available</li><li class="features-and-benefits-list-item">Small size and low weight</li><li class="features-and-benefits-list-item">Suitable for both wave and reflow soldering</li><li class="features-and-benefits-list-item">Reduction of assembly costs</li><li class="features-and-benefits-list-item">Maximum working voltage of 50V(0402, 0603), 150V(0805), 200V(1206)</li><li class="features-and-benefits-list-item">Available in ±1%, ±2% and ±5% tolerance</li><li class="features-and-benefits-list-item">Power rating of 62.5mW, 100mW and 125mW</li><li class="features-and-benefits-list-item">±400ppm/°C(1ohm to 10ohm), ±200ppm/°C(11ohm to 100ohm), ±100; Temperature Coefficient: ± 100; Voltage Rating: 50; Automotive Qualification Standard: -; Component Kind: Standard; ComponentLink1Description: http://www.farnell.com/datasheets/1788326.pdf; ComponentLink1URL: http://www.farnell.com/datasheets/1788326.pdf; ComponentLink2Description: http://www.farnell.com/datasheets/1944283.pdf; ComponentLink2URL: http://www.farnell.com/datasheets/1944283.pdf; Library Name: SCHLib.SchLib; Manufacturer: MULTICOMP; Manufacturer Part Number: MC0063W0603112K; Packaging: Tape & Reel; Pricing 1: 5000=0.0006, 25000=0.0005, 50000=0.0004 (GBP); Resistance Tolerance: ± 1%; Resistor Element Material: Thick Film; RoHS: Y-EX; Stock 1: 784996; Supplier 1: Farnell; Supplier Part Number 1: 2130943
R42 R43 = MCMR06X4702FTL: MULTICOMP - MCMR06X4702FTL - RES, CERAMIC, 47K, 1%, 0.1W, 0603; Automotive Qualification Standard: -<!--FANDB--><div class="features-and-benefits-container"><div class="features-and-benefits-description"><span class="features-benefits-value">The Multicomp MCMR series resistors are automotive grade, anti sulphured thick film chip resistor. The resistance range includes E24 and E96 decade values from 1ohm to 10Mohm. These resistors are constructed on top of a high grade ceramic body.</span></div><div class="features-and-benefits-list"><ul class="features-and-benefits-list-list"><li class="features-and-benefits-list-item">EIA 0402, 0603, 0805, 1206 chip sizes available</li><li class="features-and-benefits-list-item">High reliability and stability</li><li class="features-and-benefits-list-item">Automotive grade AEC-Q200 compliant</li><li class="features-and-benefits-list-item">Complaint with (IEC 60068-1) 55/155/56 climatic category</li><li class="features-and-benefits-list-item">Maximum operation voltage of 50V, 75V, 150V and 200V</li><li class="features-and-benefits-list-item">Power rating of 62.5mW, 100mW, 125mW and 250mW</li><li class="features-and-benefits-list-item">Available in ±1% and ±5% tolerance</li><li class="features-and-benefits-list-item">Temperature coefficient (TCR) of +200ppm/°C, +100ppm/°C and -200ppm/°C to +400ppm/°C</li><li class="features-and-benefits-list-item">Operating temperature range -55°C to 155; Power Rating: 100; Product Range: MCMR Series; Resistance: 47; Resistor Case Style: 0603 [1608 Metric]; Temperature Coefficient: ± 100; Voltage Rating: 75; Component Kind: Standard; ComponentLink1Description: http://www.farnell.com/datasheets/1716707.pdf; ComponentLink1URL: http://www.farnell.com/datasheets/1716707.pdf; Library Name: SCHLib.SchLib; Manufacturer: MULTICOMP; Manufacturer Part Number: MCMR06X4702FTL; Packaging: Cut Tape; Pricing 1: 10=0.0115, 100=0.009, 500=0.0064, 1000=0.0051, 2500=0.0042, 5000=0.0032, 25000=0.0021, 50000=0.0017 (GBP); Resistance Tolerance: ± 1%; Resistor Element Material: Ceramic; RoHS: Y-EX; Stock 1: 21427; Supplier 1: Farnell; Supplier Part Number 1: 2073510
T1 = Ethernet RJ45: 1 Port RJ45 Magjack Connector Through Hole 10/100 Base-T, AutoMDIX, Power over Ethernet (PoE); Brand: Bel Fuse; Gender: Jack; Mounting Angle: Right Angle; Number of Ports: 1 Port; Number of Positions: 8 Position; Shielding: Shielded; Category: Modular Connectors / Ethernet Connectors; Component Kind: Standard; ComponentLink1Description: http://www.mouser.com/ds/2/643/0826-1L1T-57-F-532261.pdf; ComponentLink1URL: http://www.mouser.com/ds/2/643/0826-1L1T-57-F-532261.pdf; Contact Plating: Gold; Flammability Rating: UL 94 V-0; Housing Material: Thermoplastic; Library Name: SCHLib.SchLib; Manufacturer: Bel Fuse; Manufacturer Part Number: 0826-1L1T-57-F; Minimum Order Quantity: 1; Mounting Style: Panel; Packaging: Tray; Pricing 1: 1=5.23, 10=5.02, 25=4.58, 100=4.19, 250=3.66, 500=3.56, 1000=3.03, 2500=2.82 (EUR); Product: Modular Jacks; Reeling: False; RoHS: RoHS Compliant; RoHS - Mouser: Y; Series: 826; Standard Pack Qty: 40; Stock 1: 0; Supplier 1: Mouser; Supplier 2: Digi-Key; Supplier Part Number 1: 530-0826-1L1T-57-F; Supplier Part Number 2: 507-1431-ND; Termination Style: Through Hole; Tradename: MagJack
TP17-TP20 TP22 = TEST_POINT: Test point; Library Name: SCHLib.SchLib
U5 = LAN9512: USB 2.0 Hub and 10/100  Ethernet Controller; Manufacturer: MICROCHIP; External Depth: 0.45; External Length / Height: 1.6; External Width: 0.8; No. of Pins: 2; Power Rating: 63; Product Range: MC Series; Reel Quantity: 5000; Resistance: 1; Resistance Tolerance: ± 1%; Resistor Case Style: 0603 [1608 Metric]; Resistor Element Material: Thick Film; Resistor Mounting: Surface Mount Device; SVHC: No SVHC (17-Dec-2015); Temperature Coeff. +: 100; Temperature Coefficient: ± 100; Tolerance +: 1%; Voltage Rating: 50; Voltage Rating V DC: 50V<!--FANDB--><div class="features-and-benefits-container"><div class="features-and-benefits-description"><span class="features-benefits-value">The Multicomp MC series current sense resistors are thick film chip resistors. The resistance range includes E96 and E24 decade values from 1ohm to 10Mohm.</span></div><div class="features-and-benefits-list"><ul class="features-and-benefits-list-list"><li class="features-and-benefits-list-item">EIA 0402, 0603, 0805, 1206 chip sizes available</li><li class="features-and-benefits-list-item">Small size and low weight</li><li class="features-and-benefits-list-item">Suitable for both wave and reflow soldering</li><li class="features-and-benefits-list-item">Reduction of assembly costs</li><li class="features-and-benefits-list-item">Maximum working voltage of 50V(0402, 0603), 150V(0805), 200V(1206)</li><li class="features-and-benefits-list-item">Available in ±1%, ±2% and ±5% tolerance</li><li class="features-and-benefits-list-item">Power rating of 62.5mW, 100mW and 125mW</li><li class="features-and-benefits-list-item">±400ppm/°C(1ohm to 10ohm), ±200ppm/°C(11ohm to 100ohm), ±100; Automotive Qualification Standard: -; Component Kind: Standard; ComponentLink1Description: http://www.farnell.com/datasheets/1686385.pdf; ComponentLink1URL: http://www.farnell.com/datasheets/1686385.pdf; ComponentLink2Description: http://www.farnell.com/cad/1726729.zip; ComponentLink2URL: http://www.farnell.com/cad/1726729.zip; ComponentLink3Description: http://www.farnell.com/datasheets/2158582.pdf; ComponentLink3URL: http://www.farnell.com/datasheets/2158582.pdf; ComponentLink4Description: http://www.farnell.com/datasheets/1788326.pdf; ComponentLink4URL: http://www.farnell.com/datasheets/1788326.pdf; ComponentLink5Description: http://www.farnell.com/cad/1558928.zip; ComponentLink5URL: http://www.farnell.com/cad/1558928.zip; Library Name: SCHLib.SchLib; Manufacturer Part Number: LAN9512-JZX; Operating Temperature Max: 155; Operating Temperature Min: -55; Operating Temperature Range: -55°C to +155; Packaging: Cut Tape; Pricing 1: 1=3.98, 10=3.62, 25=3.23, 50=3.05, 100=2.87 (GBP); Pricing 2: 10=0.0011, 100=0.001, 500=0.0009, 1000=0.0008, 2500=0.0007 (GBP); RoHS: YES; Stock 2: 333415; Supplier 1: Farnell; Supplier 2: Farnell; Supplier Part Number 1: 2292586; Tape Width: 8; Temperature Coeff. -: 100; Tolerance -: 1%
U6 = FSUSB42MUX: FAIRCHILD SEMICONDUCTOR - FSUSB42MUX - USB SWITCH, DUAL, DPDT, MSOP-10; Analogue Switch Case: MSOP; No. of Channels: 2; No. of Pins: 10; On State Resistance Max: 4.5; Supply Voltage Range: 2.4V to 3.6; SVHC: No SVHC (15-Jun-2015; Analogue Switch Type: DPDT; Automotive Qualification Standard: -; Component Kind: Standard; ComponentLink1Description: http://www.farnell.com/datasheets/2246555.pdf; ComponentLink1URL: http://www.farnell.com/datasheets/2246555.pdf; Library Name: SCHLib.SchLib; Manufacturer: FAIRCHILD SEMICONDUCTOR; Manufacturer Part Number: FSUSB42MUX; MSL: MSL 1 - Unlimited; Operating Temperature Max: 85; Operating Temperature Min: -40; Packaging: Cut Tape; Pricing 1: 1=0.246, 10=0.193, 100=0.187, 250=0.181, 500=0.175, 1000=0.17 (GBP); Product Range: -; RoHS: YES; Stock 1: 1029; Supplier 1: Farnell; Supplier Part Number 1: 2564479
Y1 = ABM8-25.000MHZ-B2-T: ABRACON - ABM8-25.000MHZ-B2-T - CRYSTAL, 25M, 18PF CL, 3.2X2.5MM SMT; Accuracy: ± 20; Crystal Case: SMD, 3.2mm x 2.5; Crystal Mounting Type: SMD; Frequency: 25; Frequency Stability: ± 50; Frequency Stability + / -: 50; Frequency Tolerance + / -: 20; Load Capacitance: 18; No. of Pins: 4; Product Range: ABM8 Series; SVHC: No SVHC (15-Jun-2015); Component Kind: Standard; ComponentLink1Description: http://www.farnell.com/datasheets/1883676.pdf; ComponentLink1URL: http://www.farnell.com/datasheets/1883676.pdf; Frequency Nom: 25; Library Name: SCHLib.SchLib; Manufacturer: ABRACON; Manufacturer Part Number: ABM8-25.000MHZ-B2-T; Operating Temperature Max: 70; Operating Temperature Min: -20; Operating Temperature Range: -20°C to +70; Output Frequency: 25; Packaging: Cut Tape<!--FANDB--><div class="features-and-benefits-container"><div class="features-and-benefits-description"><span class="features-benefits-value">The ABM8-25.000MHZ-B2-T is a 3.2 x 2.5 x 0.8mm 20ppm ultra miniature ceramic surface-mount Crystal features seam sealed assures high reliability, tight tolerance and stability. The ABM8 series crystal for use with the modems, communication and test equipment, PMCIA, wireless and high density applications. It is suitable for reflow profile.</span></div><div class="features-and-benefits-list"><ul class="features-and-benefits-list-list"><li class="features-and-benefits-list-item">Fundamental operation mode</li><li class="features-and-benefits-list-item">50; Pricing 1: 1=1.09, 2=0.9, 3=0.807, 5=0.745, 10=0.696, 20=0.658, 50=0.633, 100=0.621 (GBP); RoHS: YES; Stock 1: 4212; Supplier 1: Farnell; Supplier Part Number 1: 1611821
